# Altium schematic sheet Signal_Integrity_4L.SchDoc
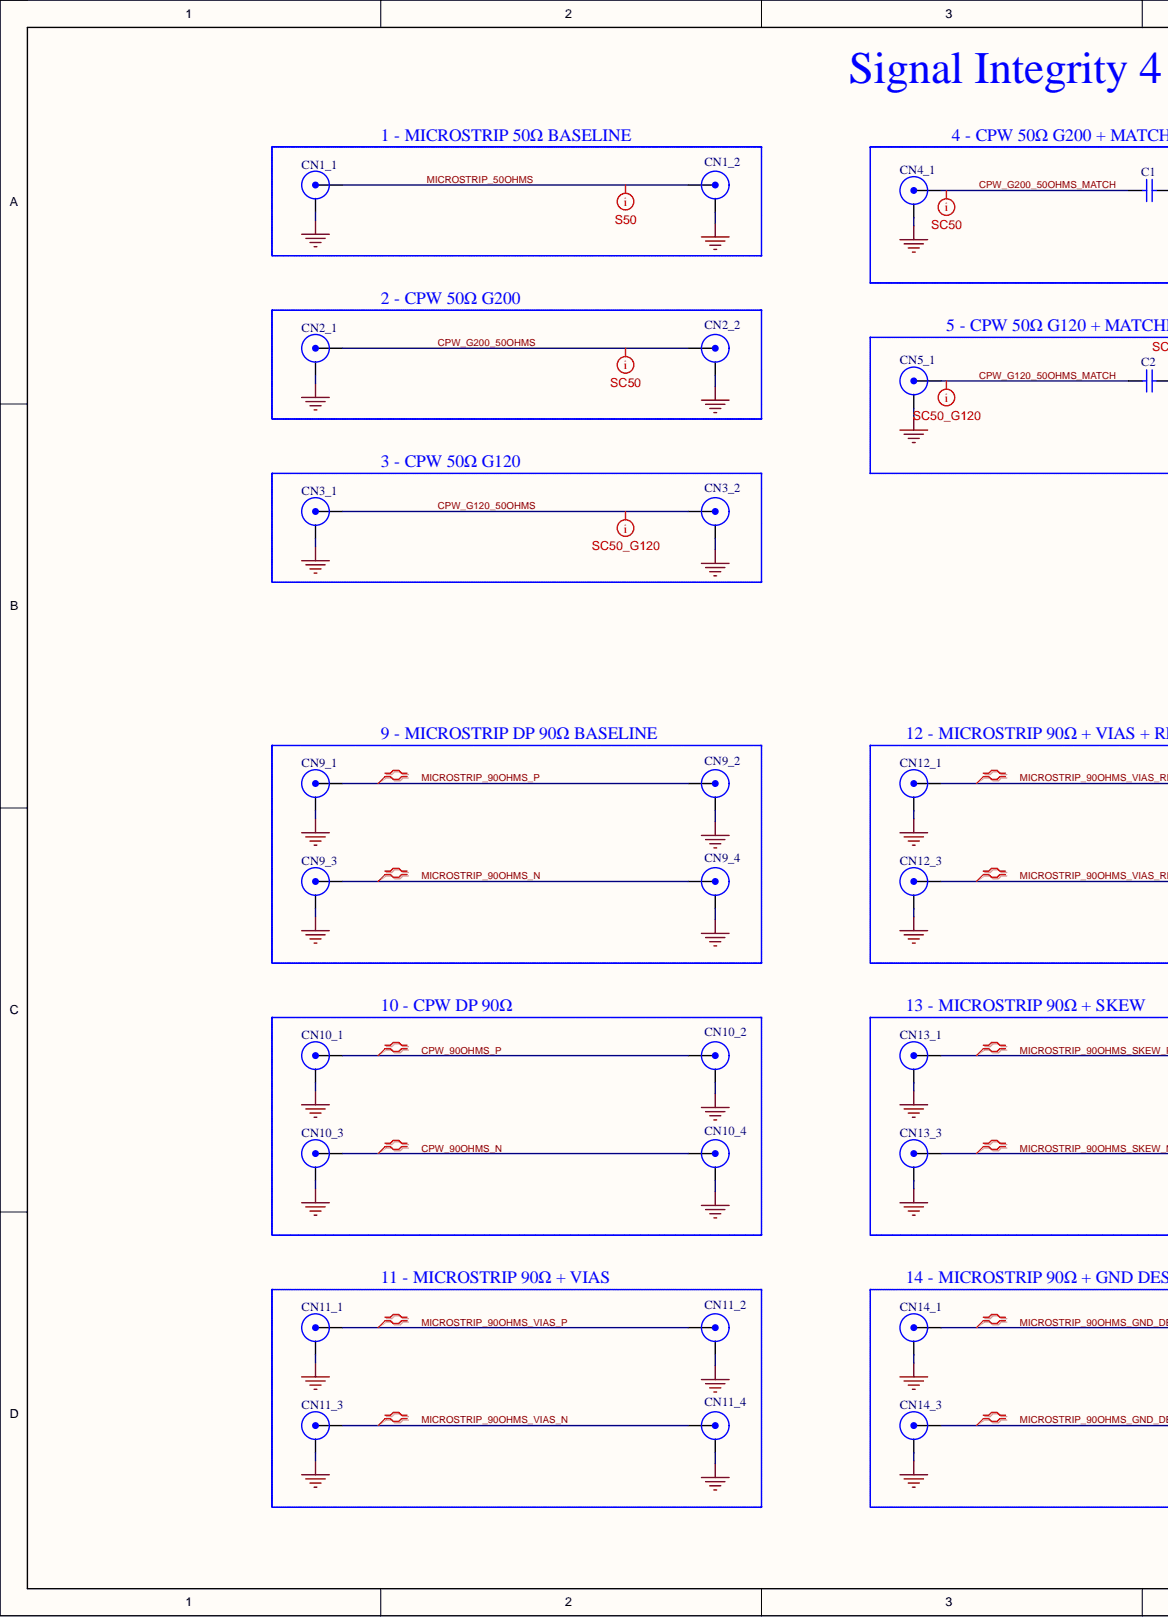
[diagram p0: Signal_Integrity_4L.SchDoc, left]
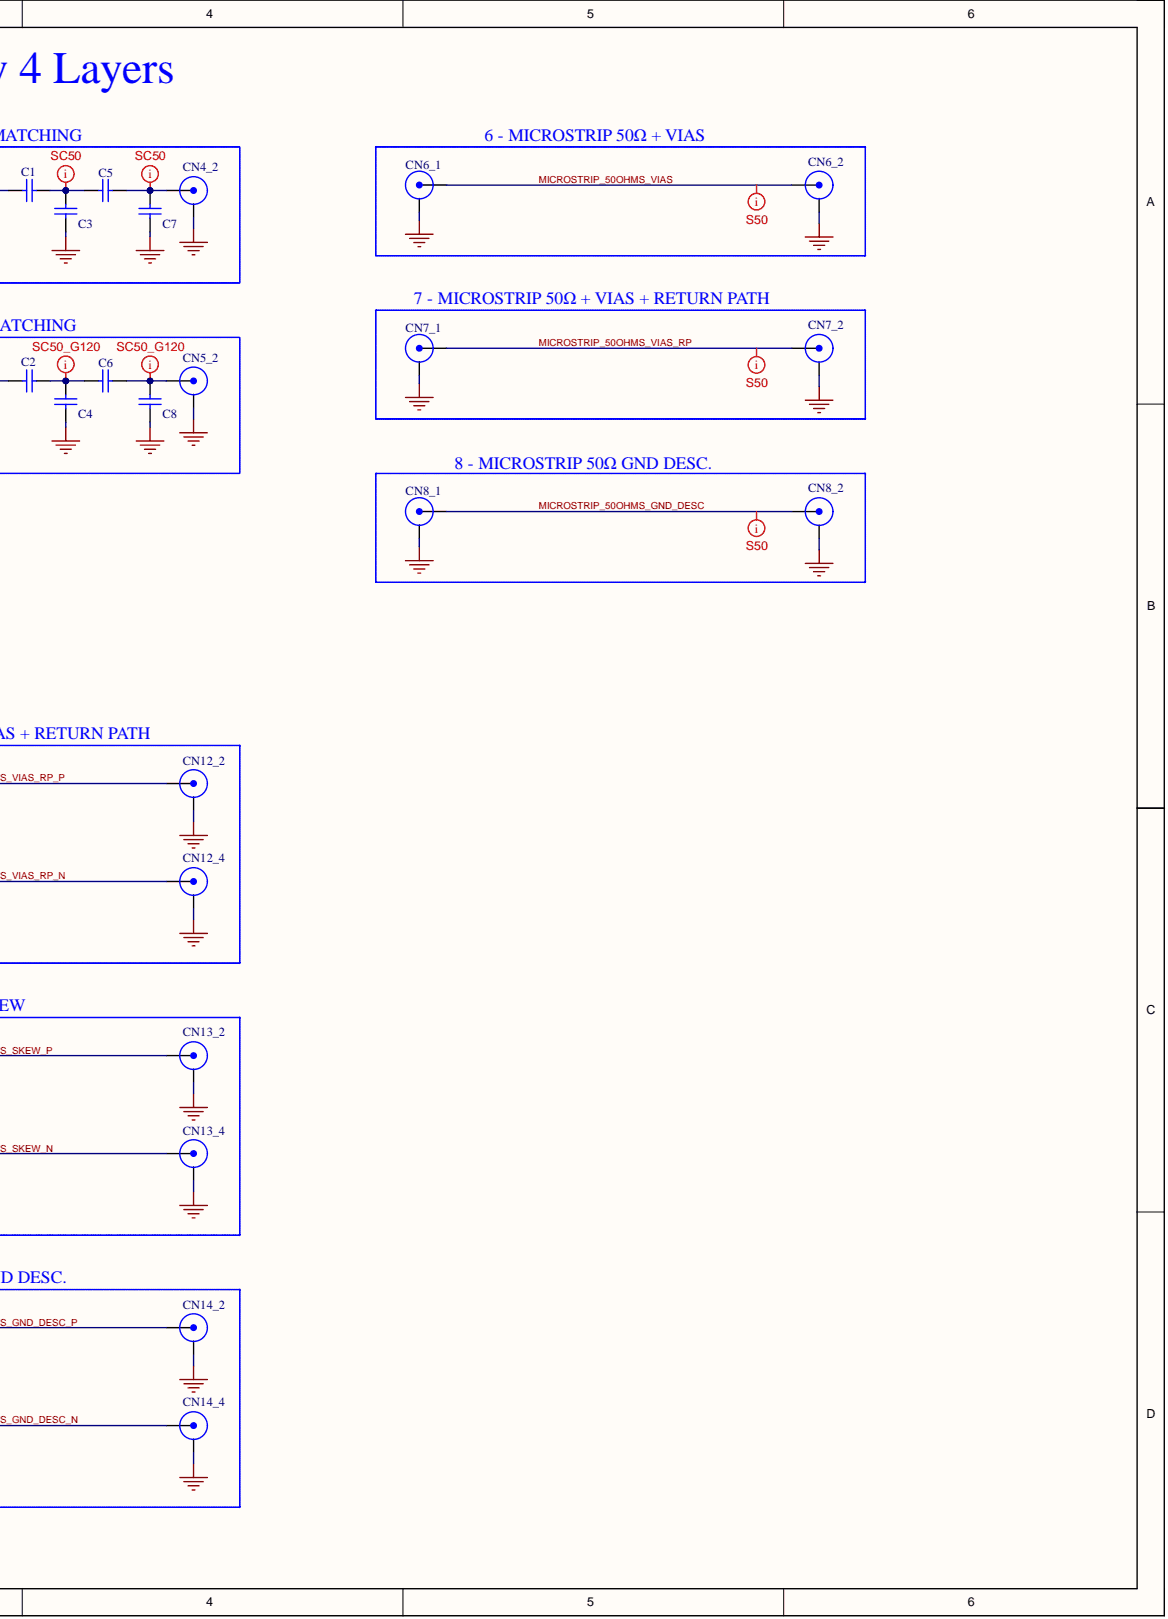
[diagram p1: Signal_Integrity_4L.SchDoc, right]

## Parts
C1  CAP SMD 0402  [0402]  (value not drawn)
C2  CAP SMD 0402  [0402]  (value not drawn)
C3  CAP SMD 0402  [0402]  (value not drawn)
C4  CAP SMD 0402  [0402]  (value not drawn)
C5  CAP SMD 0402  [0402]  (value not drawn)
C6  CAP SMD 0402  [0402]  (value not drawn)
C7  CAP SMD 0402  [0402]  (value not drawn)
C8  CAP SMD 0402  [0402]  (value not drawn)
CN1_1  SMA-KE EDGE  [SMA-KE EDGE]  (value not drawn)
CN1_2  SMA-KE EDGE  [SMA-KE EDGE]  (value not drawn)
CN2_1  SMA-KE EDGE  [SMA-KE EDGE]  (value not drawn)
CN2_2  SMA-KE EDGE  [SMA-KE EDGE]  (value not drawn)
CN3_1  SMA-KE EDGE  [SMA-KE EDGE]  (value not drawn)
CN3_2  SMA-KE EDGE  [SMA-KE EDGE]  (value not drawn)
CN4_1  SMA-KE EDGE  [SMA-KE EDGE]  (value not drawn)
CN4_2  SMA-KE EDGE  [SMA-KE EDGE]  (value not drawn)
CN5_1  SMA-KE EDGE  [SMA-KE EDGE]  (value not drawn)
CN5_2  SMA-KE EDGE  [SMA-KE EDGE]  (value not drawn)
CN6_1  SMA-KE EDGE  [SMA-KE EDGE]  (value not drawn)
CN6_2  SMA-KE EDGE  [SMA-KE EDGE]  (value not drawn)
CN7_1  SMA-KE EDGE  [SMA-KE EDGE]  (value not drawn)
CN7_2  SMA-KE EDGE  [SMA-KE EDGE]  (value not drawn)
CN8_1  SMA-KE EDGE  [SMA-KE EDGE]  (value not drawn)
CN8_2  SMA-KE EDGE  [SMA-KE EDGE]  (value not drawn)
CN9_1  SMA-KE EDGE  [SMA-KE EDGE]  (value not drawn)
CN9_2  SMA-KE EDGE  [SMA-KE EDGE]  (value not drawn)
CN9_3  SMA-KE EDGE  [SMA-KE EDGE]  (value not drawn)
CN9_4  SMA-KE EDGE  [SMA-KE EDGE]  (value not drawn)
CN10_1  SMA-KE EDGE  [SMA-KE EDGE]  (value not drawn)
CN10_2  SMA-KE EDGE  [SMA-KE EDGE]  (value not drawn)
CN10_3  SMA-KE EDGE  [SMA-KE EDGE]  (value not drawn)
CN10_4  SMA-KE EDGE  [SMA-KE EDGE]  (value not drawn)
CN11_1  SMA-KE EDGE  [SMA-KE EDGE]  (value not drawn)
CN11_2  SMA-KE EDGE  [SMA-KE EDGE]  (value not drawn)
CN11_3  SMA-KE EDGE  [SMA-KE EDGE]  (value not drawn)
CN11_4  SMA-KE EDGE  [SMA-KE EDGE]  (value not drawn)
CN12_1  SMA-KE EDGE  [SMA-KE EDGE]  (value not drawn)
CN12_2  SMA-KE EDGE  [SMA-KE EDGE]  (value not drawn)
CN12_3  SMA-KE EDGE  [SMA-KE EDGE]  (value not drawn)
CN12_4  SMA-KE EDGE  [SMA-KE EDGE]  (value not drawn)
CN13_1  SMA-KE EDGE  [SMA-KE EDGE]  (value not drawn)
CN13_2  SMA-KE EDGE  [SMA-KE EDGE]  (value not drawn)
CN13_3  SMA-KE EDGE  [SMA-KE EDGE]  (value not drawn)
CN13_4  SMA-KE EDGE  [SMA-KE EDGE]  (value not drawn)
CN14_1  SMA-KE EDGE  [SMA-KE EDGE]  (value not drawn)
CN14_2  SMA-KE EDGE  [SMA-KE EDGE]  (value not drawn)
CN14_3  SMA-KE EDGE  [SMA-KE EDGE]  (value not drawn)
CN14_4  SMA-KE EDGE  [SMA-KE EDGE]  (value not drawn)

## Nets
GND1: CN1_1.2 CN1_2.2
GND2: CN2_1.2 CN2_2.2
GND3: CN3_1.2 CN3_2.2
GND4: C3.1 C7.1 CN4_1.2 CN4_2.2
GND5: C4.1 C8.1 CN5_1.2 CN5_2.2
GND6: CN6_1.2 CN6_2.2
GND7: CN7_1.2 CN7_2.2
GND8: CN8_1.2 CN8_2.2
GND9: CN9_1.2 CN9_2.2 CN9_3.2 CN9_4.2
GND10: CN10_1.2 CN10_2.2 CN10_3.2 CN10_4.2
GND11: CN11_1.2 CN11_2.2 CN11_3.2 CN11_4.2
GND12: CN12_1.2 CN12_2.2 CN12_3.2 CN12_4.2
GND13: CN13_1.2 CN13_2.2 CN13_3.2 CN13_4.2
GND14: CN14_1.2 CN14_2.2 CN14_3.2 CN14_4.2
CPW_90OHMS_N: CN10_3.1 CN10_4.1
CPW_90OHMS_P: CN10_1.1 CN10_2.1
CPW_G120_50OHMS: CN3_1.1 CN3_2.1
CPW_G120_50OHMS_MATCH: C2.1 CN5_1.1
CPW_G200_50OHMS: CN2_1.1 CN2_2.1
CPW_G200_50OHMS_MATCH: C1.1 CN4_1.1
MICROSTRIP_50OHMS: CN1_1.1 CN1_2.1
MICROSTRIP_50OHMS_GND_DESC: CN8_1.1 CN8_2.1
MICROSTRIP_50OHMS_VIAS: CN6_1.1 CN6_2.1
MICROSTRIP_50OHMS_VIAS_RP: CN7_1.1 CN7_2.1
MICROSTRIP_90OHMS_GND_DESC_N: CN14_3.1 CN14_4.1
MICROSTRIP_90OHMS_GND_DESC_P: CN14_1.1 CN14_2.1
MICROSTRIP_90OHMS_N: CN9_3.1 CN9_4.1
MICROSTRIP_90OHMS_P: CN9_1.1 CN9_2.1
MICROSTRIP_90OHMS_SKEW_N: CN13_3.1 CN13_4.1
MICROSTRIP_90OHMS_SKEW_P: CN13_1.1 CN13_2.1
MICROSTRIP_90OHMS_VIAS_N: CN11_3.1 CN11_4.1
MICROSTRIP_90OHMS_VIAS_P: CN11_1.1 CN11_2.1
MICROSTRIP_90OHMS_VIAS_RP_N: CN12_3.1 CN12_4.1
MICROSTRIP_90OHMS_VIAS_RP_P: CN12_1.1 CN12_2.1
NetC1_2: C1.2 C3.2 C5.1
NetC2_2: C2.2 C4.2 C6.1
NetC5_2: C5.2 C7.2 CN4_2.1
NetC6_2: C6.2 C8.2 CN5_2.1

## Library data of the parts
C1-C8 = CAP SMD 0402: 0402; Component Kind: Standard; Library Name: Impedance.IntLib
CN1_1 CN1_2 CN2_1 CN2_2 CN3_1 CN3_2 CN4_1 CN4_2 CN5_1 CN5_2 CN6_1 CN6_2 CN7_1 CN7_2 CN8_1 CN8_2 CN9_1-CN9_4 CN10_1-CN10_4 CN11_1-CN11_4 CN12_1-CN12_4 CN13_1-CN13_4 CN14_1-CN14_4 = SMA-KE EDGE: SMA Connector Receptacle, Female Socket 50Ohm Board Edge, End Launch Solder; Manufacturer_Name: TE Connectivity; Manufacturer_Part_Number: CONSMA020.062-G; Geometry.Height: 7.92mm; Component Kind: Standard; Datasheet Link: https://componentsearchengine.com/Datasheets/1/CONSMA020.062-G.pdf; Mouser Part Number: 712-CONSMA020.062-G; Mouser Price/Stock: https://www.mouser.co.uk/ProductDetail/Linx-Technologies/CONSMA020.062-G?qs=xZ%2FP%252Ba9zWqa7zEs9%2FuMaNQ%3D%3D; Library Name: Impedance.IntLib
